# Altium schematic sheet dac.SchDoc(dac)
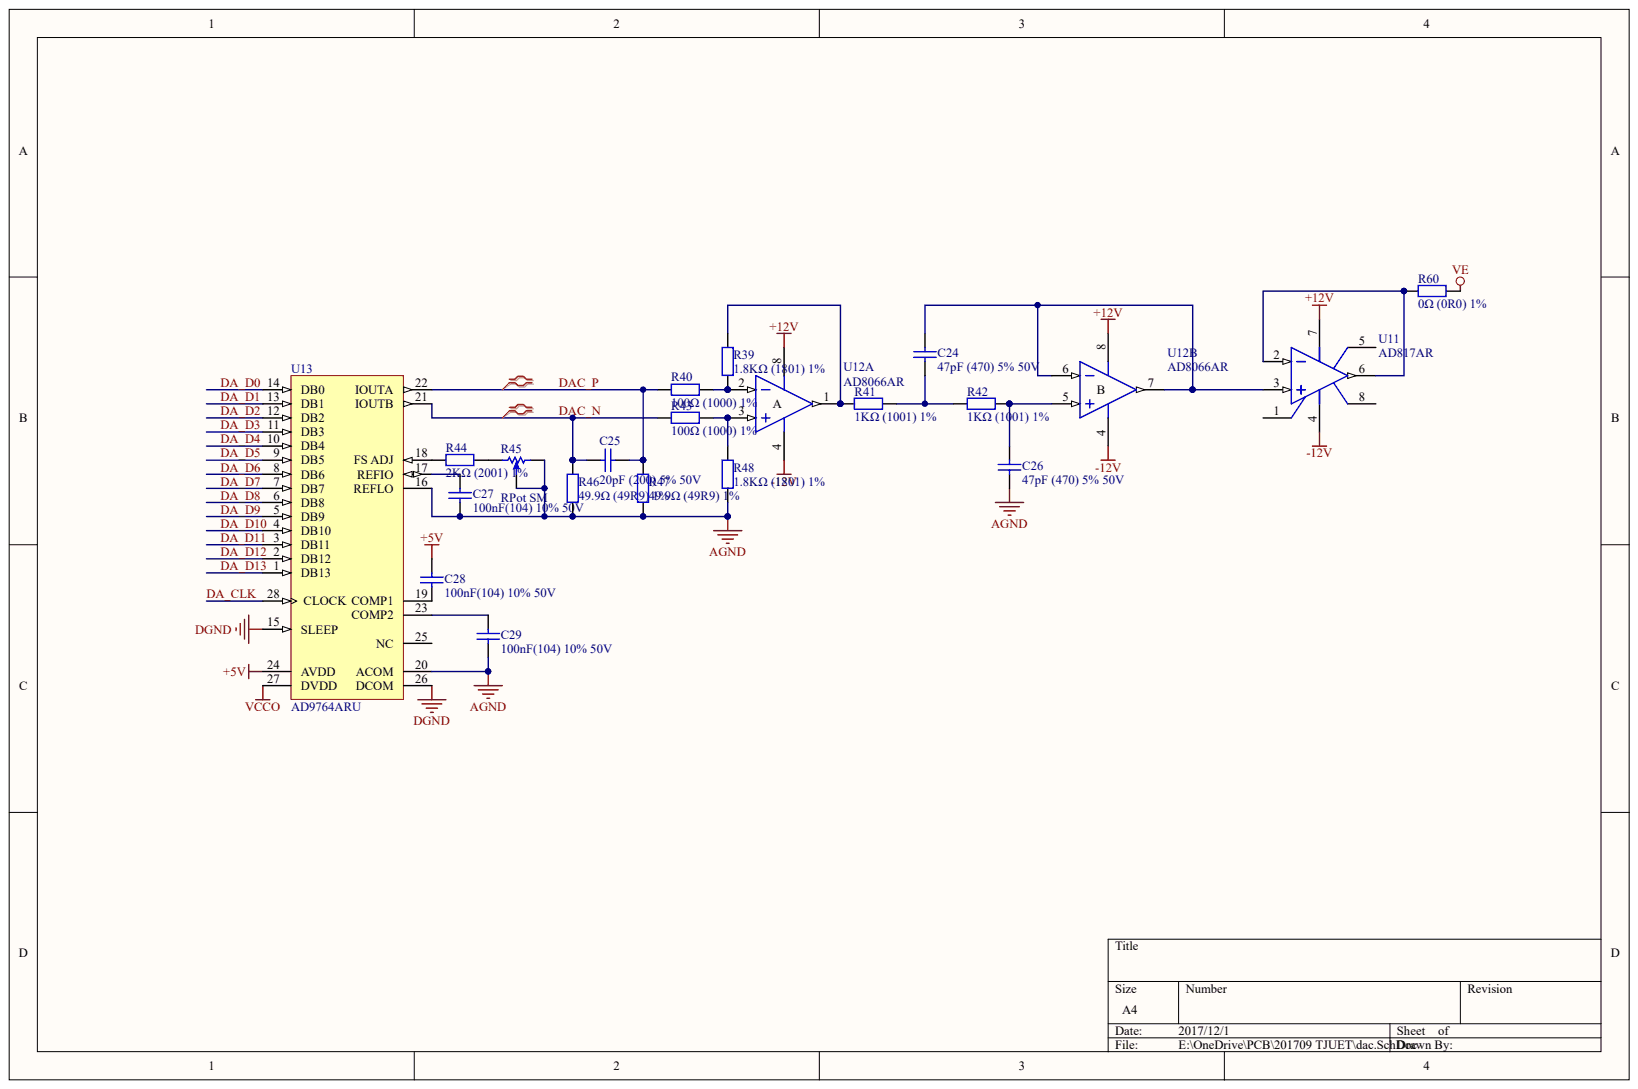
[diagram p0: dac.SchDoc]

## Parts
C24  47pF (470) 5% 50V
C25  20pF (200) 5% 50V
C26  47pF (470) 5% 50V
C27  100nF(104) 10% 50V
C28  100nF(104) 10% 50V
C29  100nF(104) 10% 50V
R39  1.8KΩ (1801) ±1%
R40  100Ω (1000) ±1%
R41  1KΩ (1001) ±1%
R42  1KΩ (1001) ±1%
R43  100Ω (1000) ±1%
R44  2KΩ (2001) ±1%
R45  RPot SM
R46  49.9Ω (49R9) ±1%
R47  49.9Ω (49R9) ±1%
R48  1.8KΩ (1801) ±1%
R60  0Ω (0R0) ±1%
U11  AD817AR
U12  AD8066AR  (parts A,B)
U13  AD9764ARU

## Nets
+5V: C28.2 U13.24(AVDD)
+12V: U11.7 U12.8
-12V: U11.4 U12.4
AGND: C26.1 C27.1 C29.1 R45.CW R45.W R46.1 R47.1 R48.1 U13.16(REFLO) U13.20(ACOM)
DGND: U13.15(SLEEP) U13.26(DCOM)
VCCO: U13.27(DVDD)
VE: R60.2
DA_CLK: U13.28(CLOCK)
DA_D0: U13.14(DB0)
DA_D1: U13.13(DB1)
DA_D2: U13.12(DB2)
DA_D3: U13.11(DB3)
DA_D4: U13.10(DB4)
DA_D5: U13.9(DB5)
DA_D6: U13.8(DB6)
DA_D7: U13.7(DB7)
DA_D8: U13.6(DB8)
DA_D9: U13.5(DB9)
DA_D10: U13.4(DB10)
DA_D11: U13.3(DB11)
DA_D12: U13.2(DB12)
DA_D13: U13.1(DB13)
DAC_N: C25.2 R43.2 R46.2 U13.21(IOUTB)
DAC_P: C25.1 R40.2 R47.2 U13.22(IOUTA)
NetC24_1: C24.1 R41.1 R42.2
NetC24_2: C24.2 U11.3 U12.6 U12.7
NetC26_2: C26.2 R42.1 U12.5
NetC27_2: C27.2 U13.17(REFIO)
NetC28_1: C28.1 U13.19(COMP1)
NetC29_2: C29.2 U13.23(COMP2)
NetR39_1: R39.1 R40.1 U12.2
NetR39_2: R39.2 R41.2 U12.1
NetR43_1: R43.1 R48.2 U12.3
NetR44_1: R44.1 R45.CCW
NetR44_2: R44.2 U13.18(FS_ADJ)
NetR60_1: R60.1 U11.2 U11.6

Not connected: U11 1 5 8; U13 25(NC)

## Library data of the parts
U11 = (no symbol name): Note: Pin 5 is not connected.; Published: 8-Jun-2000; LatestRevisionDate: 13-Apr-2006; LatestRevisionNote: IPC-7351 Footprint Added.; DatasheetVersion: REV. B 1995; PackageVersion: revA; PackageReference: SO-8; PackageDescription: 8-Pin Small Outline Integrated Circuit 1.27 mm Pitch; Code_JEDEC: MS-012-AA; Code_IPC: SOIC127P600-8; ComponentLink1Description: Manufacturer Link; ComponentLink1URL: http://www.analog.com/en/prod/0,,759_786_AD817%2C00.html; ComponentLink2Description: Datasheet; ComponentLink2URL: http://www.analog.com/UploadedFiles/Data_Sheets/289066266ad817.pdf; Publisher: Altium Limited
U13 = (no symbol name): Generic Part Number: AD9764; Manufacturer: Analog Devices; # DAC Outputs: 1; Max V+: 5.5V; Op Pwr Diss: 170mW; Packing: Tube; Resolution (Bits): 14; Settling Time: 35ns; Single Supply: Yes; DatasheetVersion: Rev.B; PackageVersion: 2005; PackageReference: RU-28; PackageDescription: 28-Lead Thin Shrink Small Outline Package [TSSOP]; Supplier 1: Digi-Key; Supplier Part Number 1: AD9764ARU-ND; Supplier 2: Mouser; Supplier Part Number 2: 584-AD9764ARU; RoHS: N; Mounting Technology: Surface Mount; Code_JEDEC: MO-153-AE; PartNumber: AD9764ARU; ComponentLink1Description: Manufacturer URL; ComponentLink1URL: http://www.analog.com/en/index.html; ComponentLink2Description: Datasheet; ComponentLink2URL: http://www.analog.com/static/imported-files/data_sheets/AD9764.pdf; ComponentLink3Description: Package Specification; ComponentLink3URL: http://www.analog.com/static/imported-files/packages/249854663RU_28.pdf; ComponentLink4Description: Product URL; ComponentLink4URL: http://www.analog.com/en/digital-to-analog-converters/da-converters/ad9764/products/product.html
C24-C29 R39-R48 R60 U12 = (no symbol name)
  C24 C26: ComponentLink1Description: 供应商链接; ComponentLink1URL: http://www.szlcsc.com/product/details_15529.html; Package: 0805; Supplier: LC; SuppliersPartNumber: C14857
  C25: ComponentLink1Description: 供应商链接; ComponentLink1URL: http://www.szlcsc.com/product/details_2150.html; Package: 0805; Supplier: LC; SuppliersPartNumber: C1798
  C27-C29: ComponentLink1Description: 供应商链接; ComponentLink1URL: http://www.szlcsc.com/product/details_50686.html; Package: 0805; Supplier: LC; SuppliersPartNumber: C49678
  R39 R48: ComponentLink1Description: 供应商链接; ComponentLink1URL: http://www.szlcsc.com/product/details_18086.html; Package: 0805; Supplier: LC; SuppliersPartNumber: C17398
  R40 R43: ComponentLink1Description: 供应商链接; ComponentLink1URL: http://www.szlcsc.com/product/details_18096.html; Package: 0805; Supplier: LC; SuppliersPartNumber: C17408
  R41 R42: ComponentLink1Description: 供应商链接; ComponentLink1URL: http://www.szlcsc.com/product/details_18201.html; Package: 0805; Supplier: LC; SuppliersPartNumber: C17513
  R44: ComponentLink1Description: 供应商链接; ComponentLink1URL: http://www.szlcsc.com/product/details_18292.html; Package: 0805; Supplier: LC; SuppliersPartNumber: C17604
  R45: Published: 17-Dec-2003; DatasheetVersion: Oct-2003; PackageReference: POT4MM-2; PackageDescription: Square Trimming Potentiometer; ComponentLink1Description: Manufacturer Link; ComponentLink1URL: http://bourns.com/2/search.html
  R46 R47: ComponentLink1Description: 供应商链接; ComponentLink1URL: http://www.szlcsc.com/product/details_18408.html; Package: 0805; Supplier: LC; SuppliersPartNumber: C17720
  R60: ComponentLink1Description: 供应商链接; ComponentLink1URL: http://www.szlcsc.com/product/details_18165.html; Package: 0805; Supplier: LC; SuppliersPartNumber: C17477
  U12: Published: 8-Jun-2000; LatestRevisionDate: 13-Apr-2006; LatestRevisionNote: IPC-7351 Footprint Added.; DatasheetVersion: REV. 0 1997; PackageVersion: revA; PackageReference: SO-8; PackageDescription: 8-Pin Small Outline Integrated Circuit 1.27 mm Pitch; Code_JEDEC: MS-012-AA; Code_IPC: SOIC127P600-8; ComponentLink1Description: Manufacturer Link; ComponentLink1URL: http://www.analog.com/en/prod/0,,759_786_AD8055%2C00.html; ComponentLink2Description: Datasheet; ComponentLink2URL: http://www.analog.com/UploadedFiles/Data_Sheets/40402558757264AD8055_6_i.pdf
  R45 U12: Publisher: Altium Limited
